# Altium schematic sheet RP2040_minimal.SchDoc(RP2040_minimal)
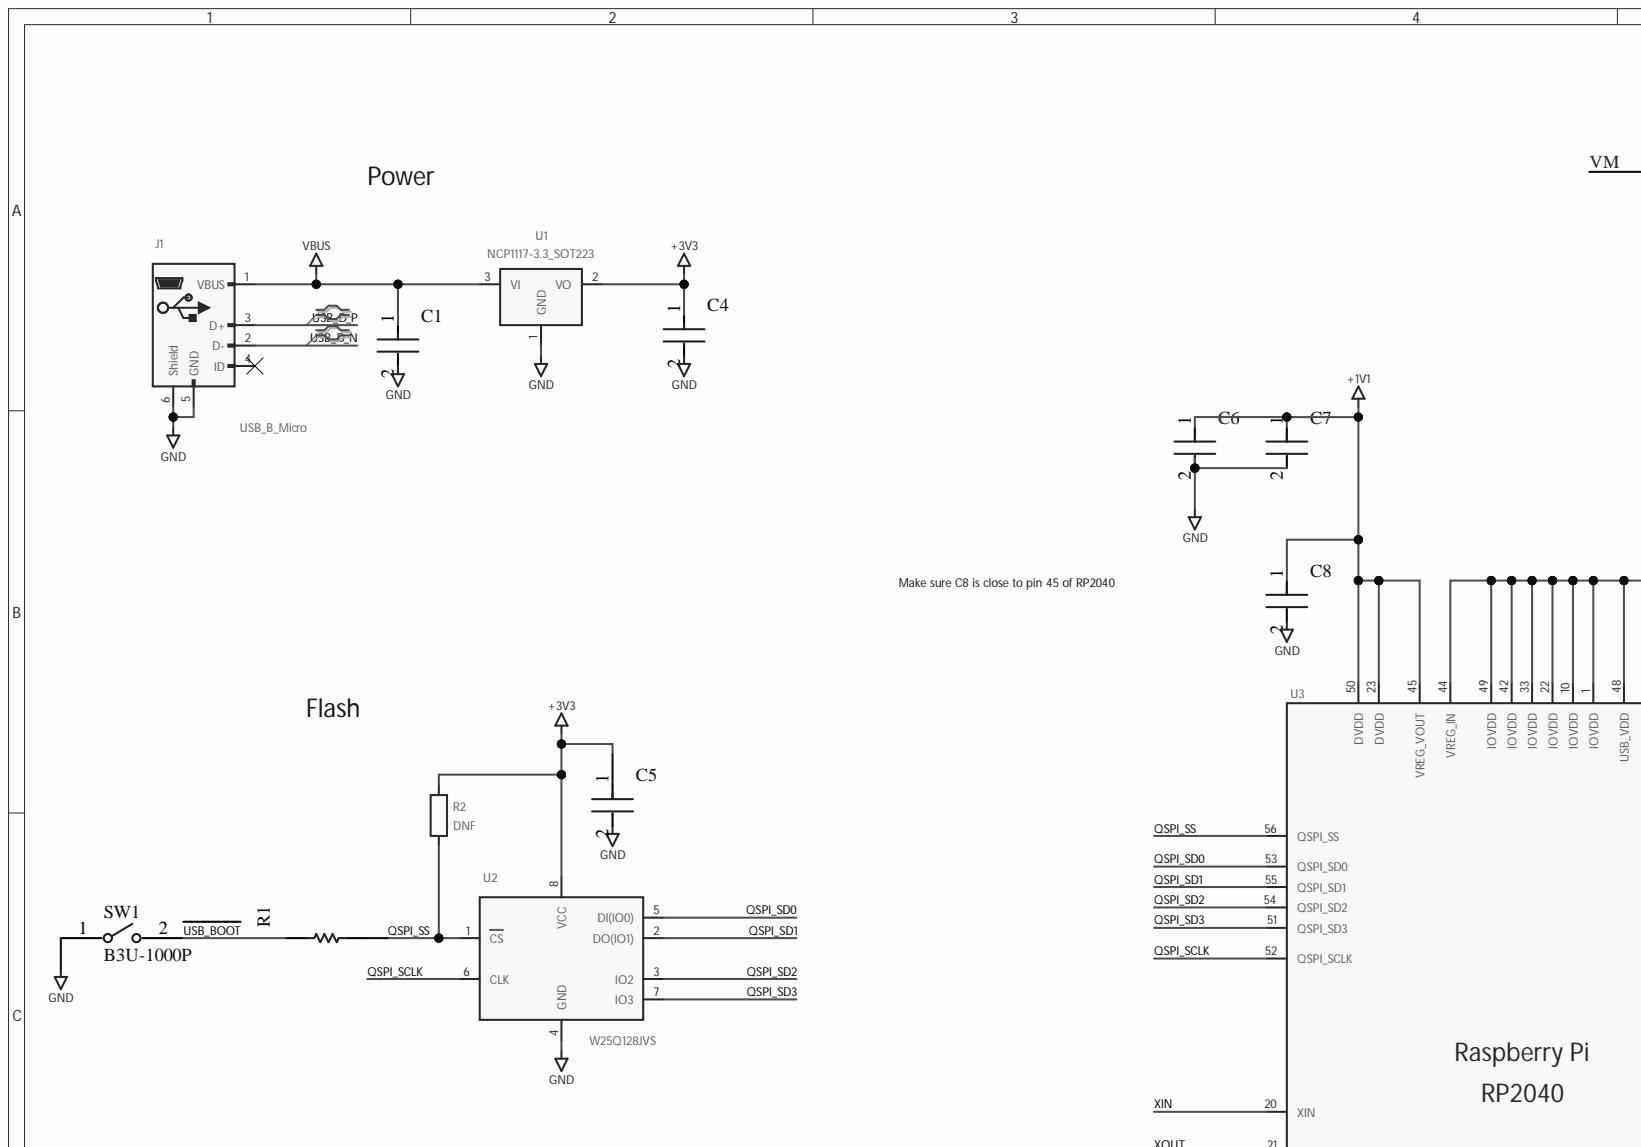
[diagram p0: RP2040_minimal.SchDoc, top left]
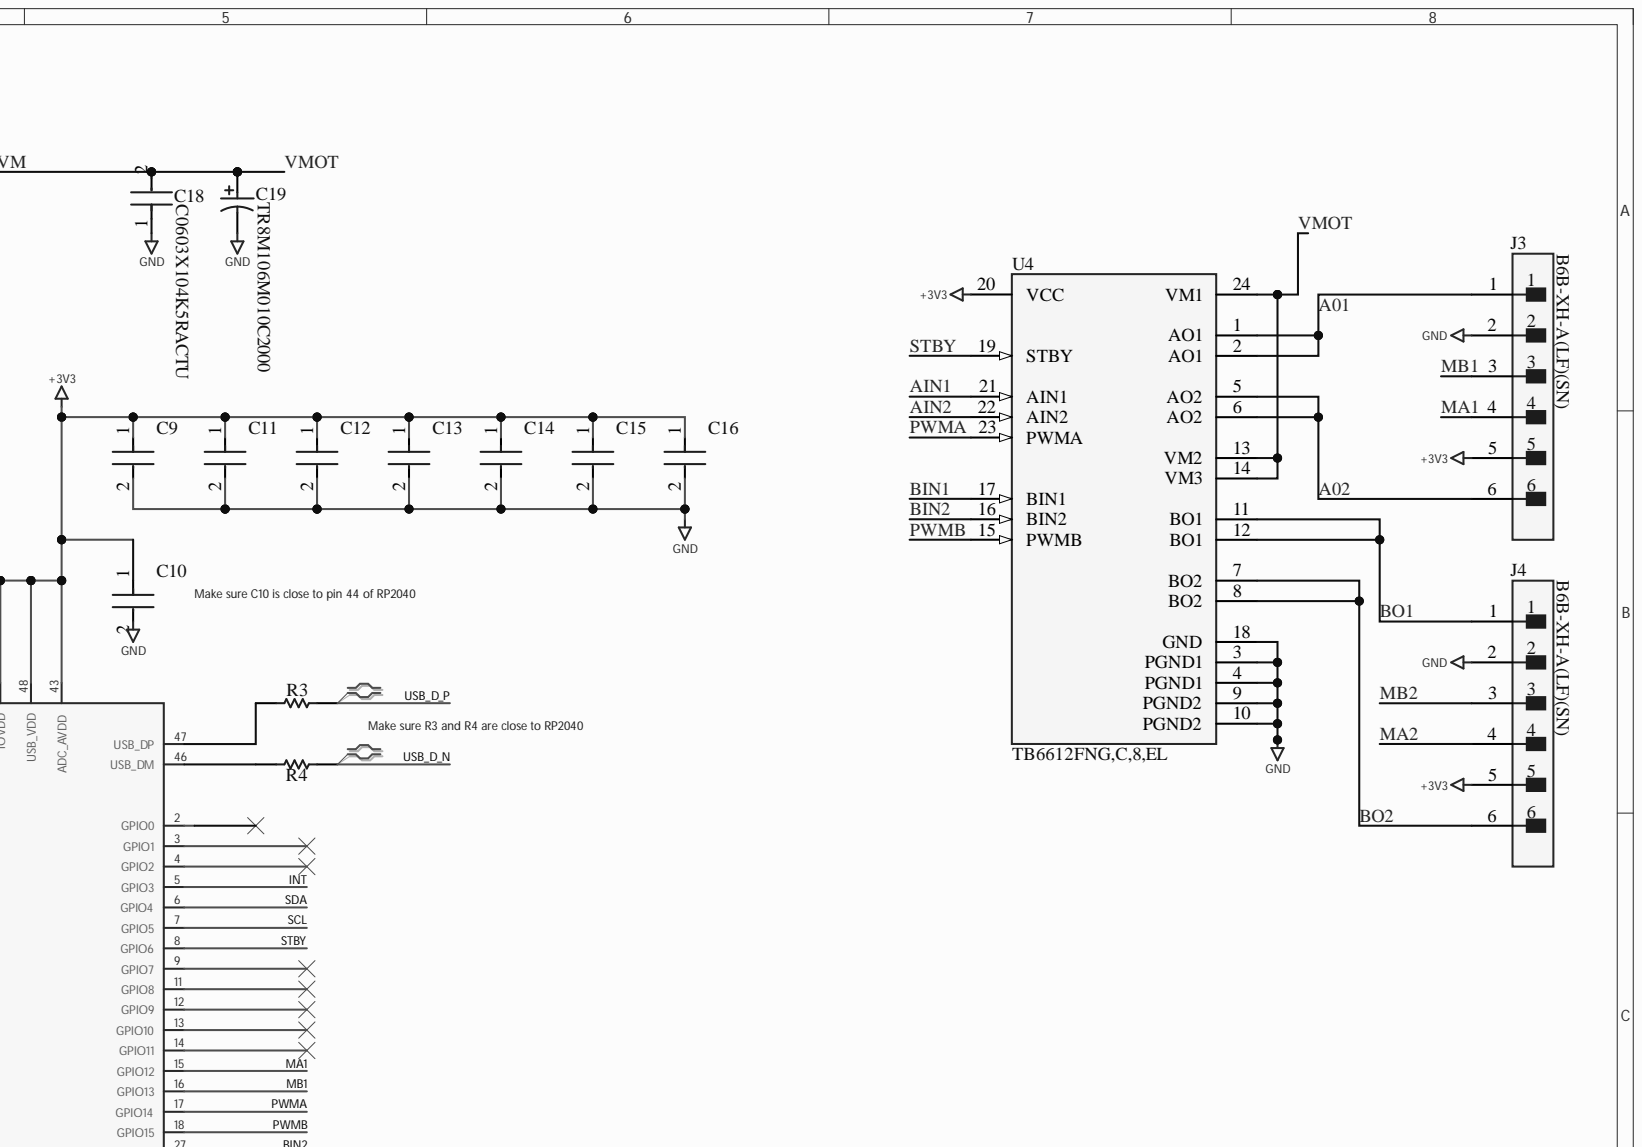
[diagram p1: RP2040_minimal.SchDoc, top right]
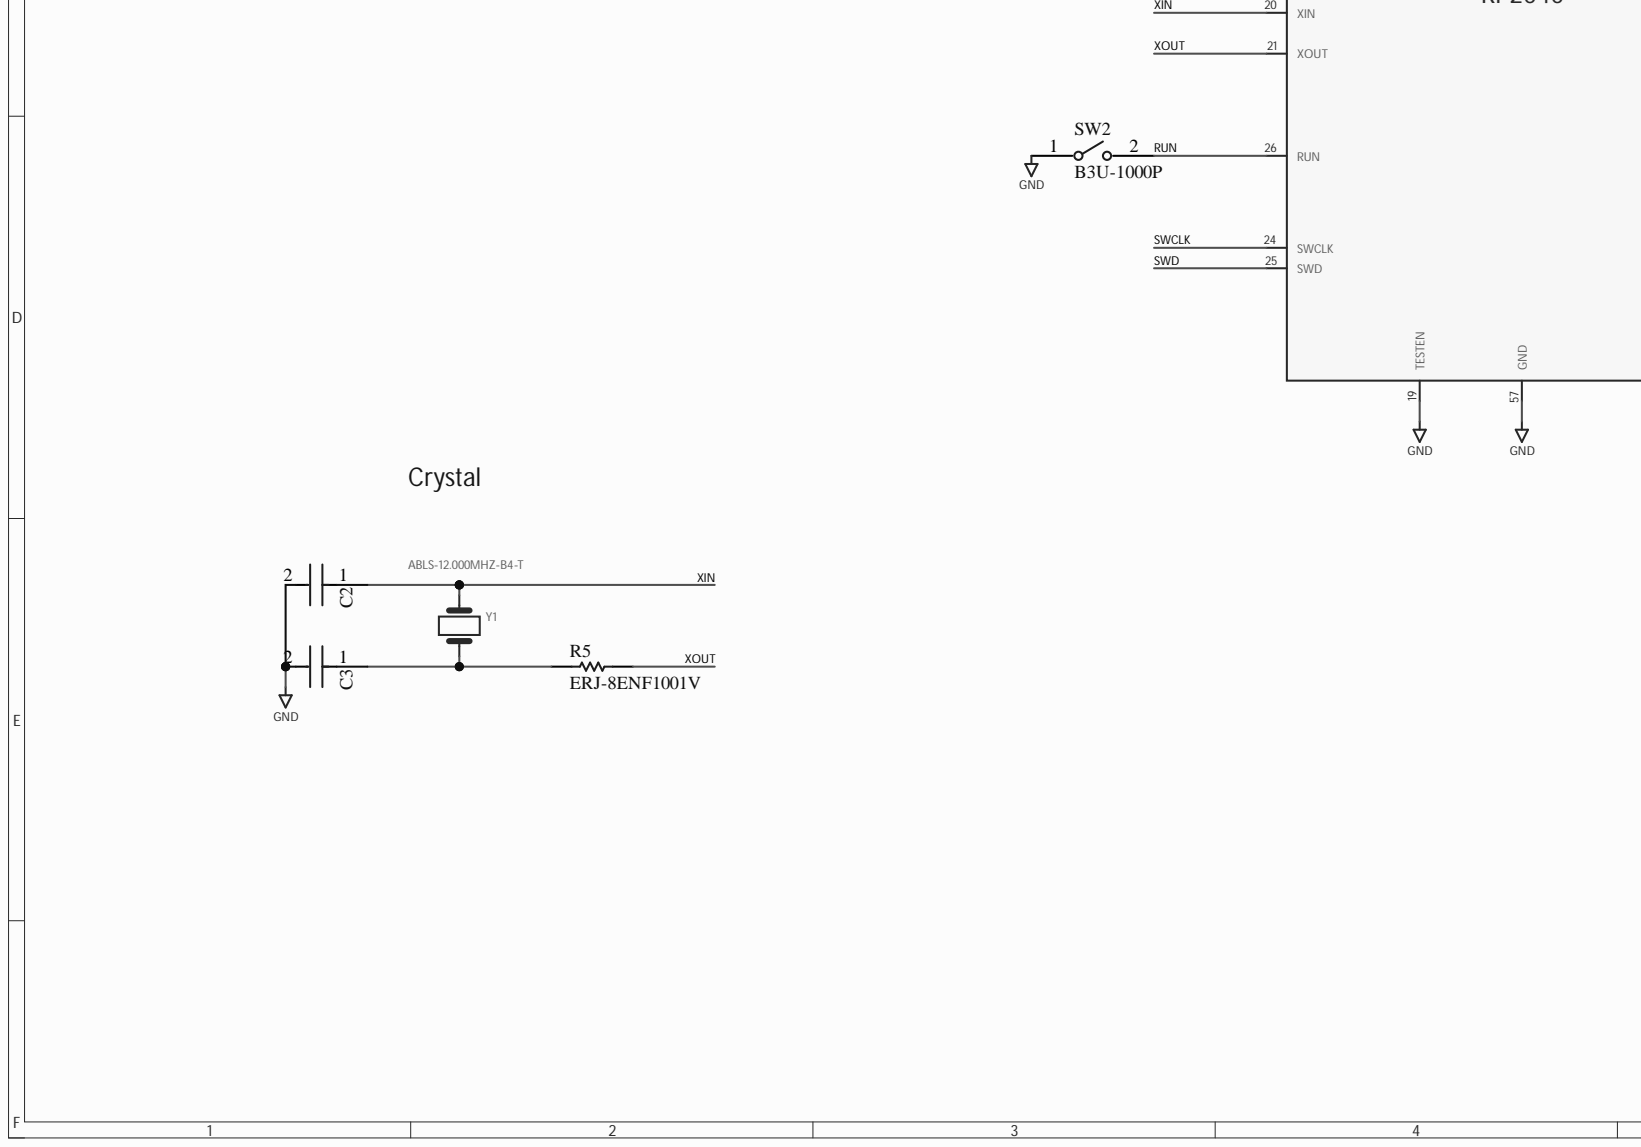
[diagram p2: RP2040_minimal.SchDoc, bottom left]
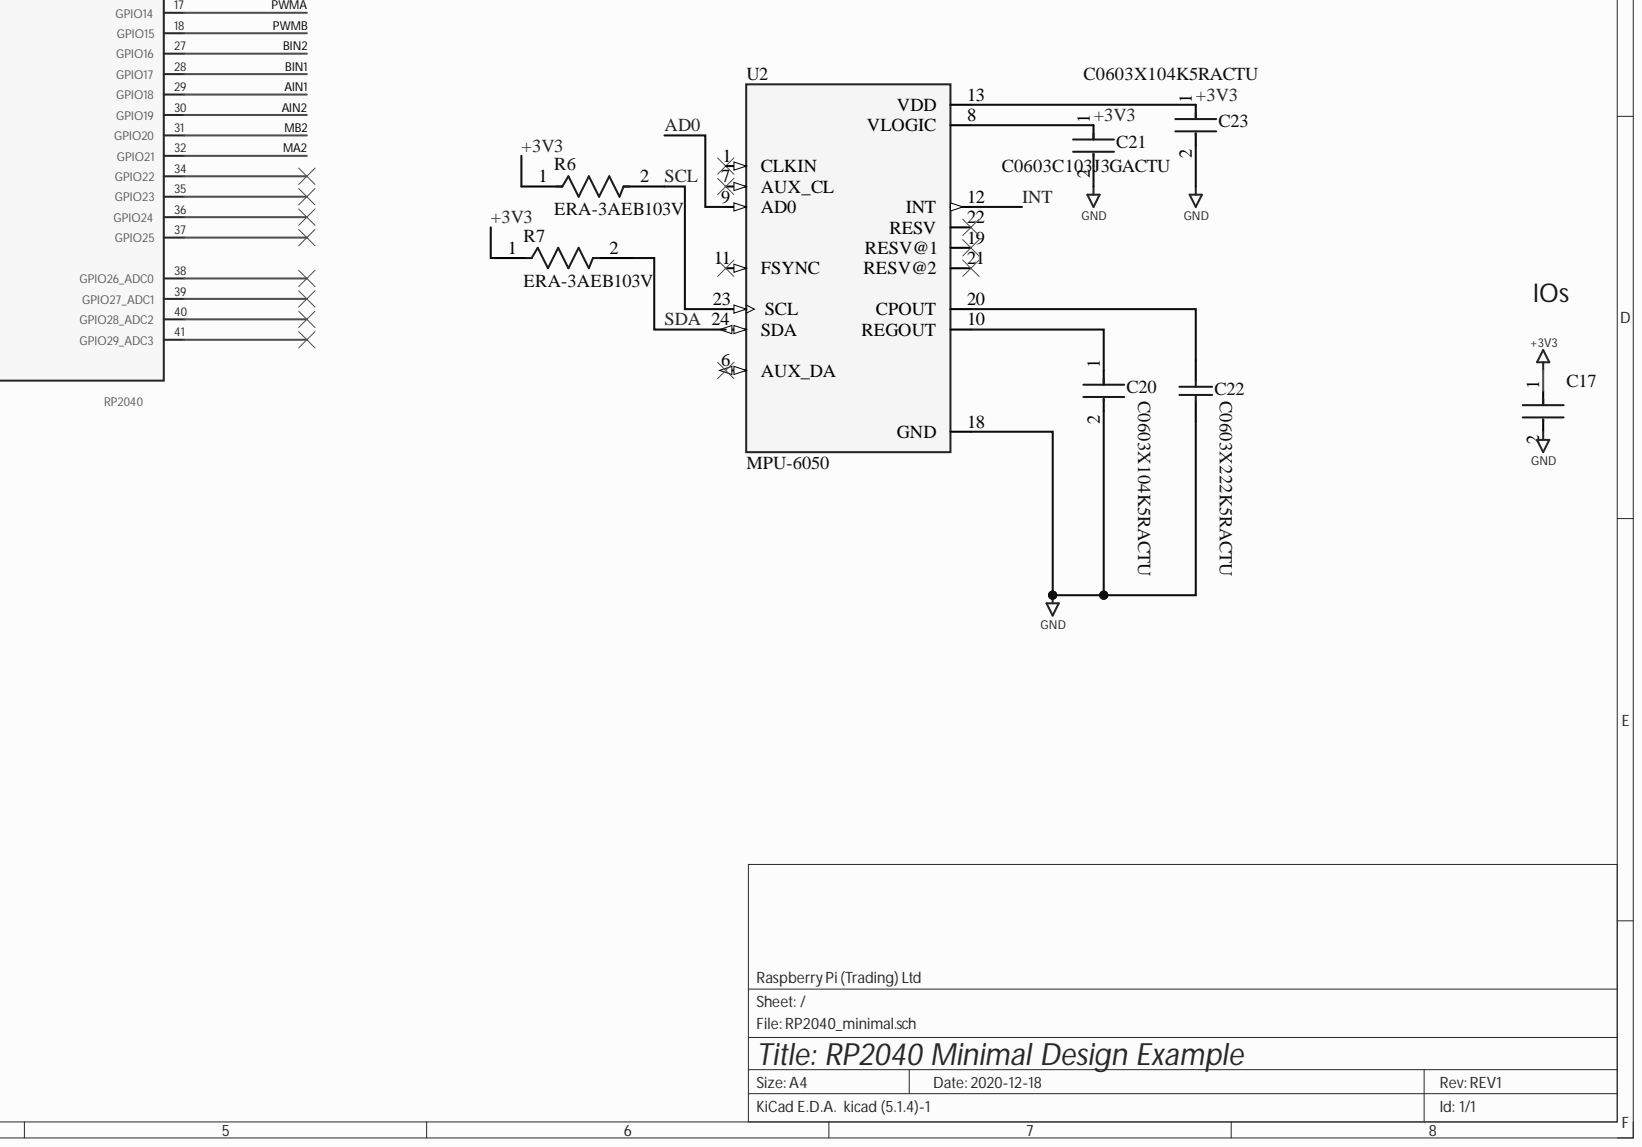
[diagram p3: RP2040_minimal.SchDoc, bottom right]

## Parts
C1  GRM21BR61C106KE15K  [FP-GRM21B-0_1-MFG]  (value not drawn)
C2  GCM1555C1H270JA16D  [FP-GCM155-0_05-IPC_B]  (value not drawn)
C3  GCM1555C1H270JA16D  [FP-GCM155-0_05-IPC_B]  (value not drawn)
C4  GRM21BR61C106KE15K  [FP-GRM21B-0_1-MFG]  (value not drawn)
C5  GRM155R71E104KE14J  [FP-GRM155-0_05-IPC_C]  (value not drawn)
C6  GRM155R71E104KE14J  [FP-GRM155-0_05-IPC_C]  (value not drawn)
C7  GRM155R71E104KE14J  [FP-GRM155-0_05-IPC_C]  (value not drawn)
C8  GCM1555C1H270JA16D  [FP-GCM155-0_05-IPC_B]  (value not drawn)
C9  GRM155R71E104KE14J  [FP-GRM155-0_05-IPC_C]  (value not drawn)
C10  GCM1555C1H270JA16D  [FP-GCM155-0_05-IPC_B]  (value not drawn)
C11  GRM155R71E104KE14J  [FP-GRM155-0_05-IPC_C]  (value not drawn)
C12  GRM155R71E104KE14J  [FP-GRM155-0_05-IPC_C]  (value not drawn)
C13  GRM155R71E104KE14J  [FP-GRM155-0_05-IPC_C]  (value not drawn)
C14  GRM155R71E104KE14J  [FP-GRM155-0_05-IPC_C]  (value not drawn)
C15  GRM155R71E104KE14J  [FP-GRM155-0_05-IPC_C]  (value not drawn)
C16  GRM155R71E104KE14J  [FP-GRM155-0_05-IPC_C]  (value not drawn)
C17  GRM21BR61C106KE15K  [FP-GRM21B-0_1-MFG]  (value not drawn)
C18  C0603X104K5RACTU  [FP-C0603-CJ-MFG]
C19  TR8M106M010C2000  [VISH-TR8-M_L]
C20  C0603X104K5RACTU  [FP-C0603-CJ-MFG]
C21  C0603C103J3GACTU  [FP-C0603C-CF-MFG]
C22  C0603X222K5RACTU  [CAPC1608X87X35NL15T15]
C23  C0603X104K5RACTU  [FP-C0603-CJ-MFG]
J1  USB_B_Micro  [RP2040_minimal:USB_Micro-B_Amphenol_10103594-0001LF_Horizontal_modified]
J3  B6B-XH-A(LF)(SN)  [FP-B6B-XH-A_LF_SN-MFG]
J4  B6B-XH-A(LF)(SN)  [FP-B6B-XH-A_LF_SN-MFG]
R1  RC0402FR-0727R4L  [RESC1005X40X25ML05T10]  (value not drawn)
R2  DNF  [Capacitor_SMD:C_0402_1005Metric]
R3  RC0402FR-0727R4L  [RESC1005X40X25ML05T10]  (value not drawn)
R4  RC0402FR-0727R4L  [RESC1005X40X25ML05T10]  (value not drawn)
R5  ERJ-8ENF1001V  [0402]
R6  ERA-3AEB103V  [FP-ERA3A-IPC_C]
R7  ERA-3AEB103V  [FP-ERA3A-IPC_C]
SW1  B3U-1000P  [FP-B3U-1000P-MFG]
SW2  B3U-1000P  [FP-B3U-1000P-MFG]
U1  NCP1117-3.3_SOT223  [Package_TO_SOT_SMD:SOT-223-3_TabPin2]
U2  MPU-6050  [QFN50P400X400X95-24N]
U2  W25Q128JVS  [Package_SO:SOIC-8_5.23x5.23mm_P1.27mm]
U3  RP2040  [RP2040_minimal:RP2040-QFN-56]
U4  TB6612FNG,C,8,EL  [FP-SSOP24-P-300-0_65A-IPC_A]
Y1  ABLS-12.000MHZ-B4-T  [RP2040_minimal:Crystal_SMD_HC49-US]

## Nets
+1V1: C6.1 C7.1 C8.1 U3.23(DVDD) U3.45(VREG_VOUT) U3.50(DVDD)
GND: C1.2 C2.2 C3.2 C4.2 C5.2 C6.2 C7.2 C8.2 C9.2 C10.2 C11.2 C12.2 C13.2 C14.2 C15.2 C16.2 C17.2 C18.1 C19.2 C20.2 C21.2 C22.2 C23.2 J1.5(GND) J1.6(Shield) J3.2 J4.2 SW1.1 SW2.1 U1.1 U2.4(GND) U2.18(GND) U3.19(TESTEN) U3.57(GND) U4.3(PGND1) U4.4(PGND1) U4.9(PGND2) U4.10(PGND2) U4.18(GND)
VBUS: C1.1 J1.1(VBUS) U1.3
+3V3: C4.1 C5.1 C9.1 C10.1 C11.1 C12.1 C13.1 C14.1 C15.1 C16.1 C17.1 C21.1 C23.1 J3.5 J4.5 R2.1 R6.1 R7.1 U1.2 U2.8 U2.13(VDD) U3.1(IOVDD) U3.10(IOVDD) U3.22(IOVDD) U3.33(IOVDD) U3.42(IOVDD) U3.43(ADC_AVDD) U3.44(VREG_IN) U3.48(USB_VDD) U3.49(IOVDD) U4.20(VCC)
A01: J3.1 U4.1(AO1) U4.2(AO1)
A02: J3.6 U4.5(AO2) U4.6(AO2)
AD0: U2.9(AD0)
AIN1: U3.29(GPIO18) U4.21(AIN1)
AIN2: U3.30(GPIO19) U4.22(AIN2)
BIN1: U3.28(GPIO17) U4.17(BIN1)
BIN2: U3.27(GPIO16) U4.16(BIN2)
BO1: J4.1 U4.11(BO1) U4.12(BO1)
BO2: J4.6 U4.7(BO2) U4.8(BO2)
INT: U2.12(INT) U3.5(GPIO3)
MA1: J3.4 U3.15(GPIO12)
MA2: J4.4 U3.32(GPIO21)
MB1: J3.3 U3.16(GPIO13)
MB2: J4.3 U3.31(GPIO20)
PWMA: U3.17(GPIO14) U4.23(PWMA)
PWMB: U3.18(GPIO15) U4.15(PWMB)
QSPI_SCLK: U2.6 U3.52(QSPI_SCLK)
QSPI_SD0: U2.5(DI(IO0)) U3.53(QSPI_SD0)
QSPI_SD1: U2.2(DO(IO1)) U3.55(QSPI_SD1)
QSPI_SD2: U2.3(IO2) U3.54(QSPI_SD2)
QSPI_SD3: U2.7 U3.51(QSPI_SD3)
QSPI_SS: R1.2 R2.2 U2.1 U3.56(QSPI_SS)
RUN: SW2.2 U3.26(RUN)
SCL: R6.2 U2.23(SCL) U3.7(GPIO5)
SDA: R7.2 U2.24(SDA) U3.6(GPIO4)
STBY: U3.8(GPIO6) U4.19(STBY)
SWCLK: U3.24(SWCLK)
SWD: U3.25(SWD)
~{USB_BOOT}: R1.1 SW1.2
USB_D_N: J1.2(D-) R4.2
USB_D_P: J1.3(D+) R3.2
VM: C18.2 C19.1 U4.13(VM2) U4.14(VM3) U4.24(VM1)
XIN: C2.1 U3.20(XIN) Y1.1
XOUT: R5.2 U3.21(XOUT)
NetC3_1: C3.1 R5.1 Y1.2
NetC20_1: C20.1 U2.10(REGOUT)
NetC22_1: C22.1 U2.20(CPOUT)
NetR3_1: R3.1 U3.47(USB_DP)
NetR4_1: R4.1 U3.46(USB_DM)

Not connected: J1 4(ID); U2 11(FSYNC) 19(RESV@1) 21(RESV@2) 22(RESV); U3 2(GPIO0) 3(GPIO1) 4(GPIO2) 9(GPIO7) 11(GPIO8) 12(GPIO9) 13(GPIO10) 14(GPIO11) 34(GPIO22) 35(GPIO23) 36(GPIO24) 37(GPIO25) 38(GPIO26_ADC0) 39(GPIO27_ADC1) 40(GPIO28_ADC2) 41(GPIO29_ADC3)

## Library data of the parts
C1 C4 C17 = CMP-2007-03534-2: Chip Multilayer Ceramic Capacitors for General Purpose, 0805, 10uF, X5R, 15%, 10%, 16V; Capacitance: 10uF; Case Code (Imperial): 0805; Case Code (Metric): 2012; Case/Package: 0805; Component Kind: Standard; Depth: 1.25mm; Dielectric: X5R; Height: 1.25mm; Length: 2mm; Library Name: Altium Content Vault; Max Operating Temperature: 85°C; Min Operating Temperature: -55°C; Mount: Surface Mount; Package Quantity: 10000; Packaging: Tape and Reel; Radiation Hardening: No; REACH SVHC: No SVHC; Termination: SMD/SMT; Thickness: 0.053inch; Tolerance: 10%; Voltage: 16V; Voltage Rating: 16V; Voltage Rating (DC): 16V; Width: 0.049inch
C2 C3 C8 C10 = CMP-06035-017587-1: Ceramic Capacitor for Automotive 27pF ±5% 50VDC C0G 0402 Paper T/R; Voltage Rating: 50V; Capacitance: 27pF; Case Code (Imperial): 0402; Case Code (Metric): 1005; Case/Package: 0402; Component Kind: Standard; Dielectric: C0G; Height: 0.5mm; Length: 0.039inch; Library Name: Altium Content Vault; Max Operating Temperature: 125°C; Min Operating Temperature: -55°C; Mount: Surface Mount; Package Quantity: 10000; Packaging: Tape and Reel; Ratings: AEC-Q200; Termination: SMD/SMT; Thickness: 0.022inch; Tolerance: 5%; Voltage Rating (DC): 50V; Weight: 0.000212oz; Width: 0.02inch
C5-C7 C9 C11-C16 = CMP-06035-003995-1: Chip Multilayer Ceramic Capacitors for General Purpose, 0402, 0.10uF, X7R, 15%, 10%, 25V; Capacitance: 100nF; Case Code (Imperial): 0402; Case Code (Metric): 1005; Case/Package: 0402; Component Kind: Standard; Dielectric: X7R; Height: 0.5mm; Length: 0.039inch; Library Name: Altium Content Vault; Max Operating Temperature: 125°C; Min Operating Temperature: -55°C; Mount: Surface Mount; Package Quantity: 50000; Packaging: Tape and Reel; Resistor Type: Ceramic; Termination: SMD/SMT; Thickness: 0.022inch; Tolerance: 10%; Voltage Rating: 25V; Voltage Rating (DC): 25V; Weight: 0.000212oz; Width: 0.5mm
C18 C20 C23 = CMP-2006-03300-2: CAP CER 0.1UF 50V X7R 0603; Manufacturer: Kemet; Capacitance: 100nF; Material: Ceramic; Temperature Coefficient: 15%; Tolerance: 10%; Value: 100nF; Automotive: No; Category: Cap; Component Kind: Standard; ComponentLink2Description: Datasheet; ComponentLink2URL: https://content.kemet.com/datasheets/KEM_C1013_X7R_FT-CAP_SMD.pdf; Device Class L1: Passive Components; Device Class L2: Capacitors; Device Class L3: Ceramic Capacitors; Digikey Description: CAP CER 0.1UF 50V X7R 0603; Height: 0.95mm; Ipc Land Pattern Name: CAPC16080X80; Lead Free: Yes; Library Name: Altium Content Vault; Manufacturer Part Number: C0603X104K5RACTU; Mouser Description: Capacitor; Ceramic; Cap 0.1uF; Tol+10%; SMT; Flexible Termination; Vol-Rtg 50V; TR; Octopart: https://octopart.com/c0603x104k5ractu-kemet-9145062; Package: C0603-CJ; RoHS: TRUE; Temperature: -55°C to +125°C; Temperature Characteristic: X7R; Temperature Range High: +125°C; Temperature Range Low: -55°C; Voltage: 50V; Voltage Rating: 50V
C19 = CMP-2000-07316-1: Tantalum Capacitor, 10 uF, +/- 20%, 10 V, -55 to 125 degC, 2-Pin SMD (M), RoHS, Tape and Reel; Manufacturer: Vishay; Capacitance: 10µF; Catalog Drawings: TR8SeriesTR8SeriesBottom; ESR (Equivalent Series Resistance): 2Ohm; Featured Product: TR8SeriesMoldedMicroTan™TantalumChipCapacitors; Online Catalog: MICROTAN®TR8Series; Other Names: 718-1673-6; Type: Molded; Voltage - Rated: 10V; Category: Capacitors; Component Kind: Standard; Datasheet URL: http://www.vishay.com/docs/40114/tr8.pdf; Datasheet Version: 03/2016; Family: TantalumCapacitors; Features: GeneralPurpose; Height - Seated (Max): 0.035"(0.89mm); Lead Spacing: -; Library Name: Altium Content Vault; Lifetime @ Temp.: -; Manufacturer Size Code: M; Manufacturer URL: http://www.vishay.com/; Mounting Technology: SurfaceMount; Mounting Type: SurfaceMount; Operating Temperature: -55°C~125°C; Package / Case: 0603(1608Metric); Package Description: 2-Pin Surface Mount Device, Body 1.6 x 0.85 mm; Package Reference: TR8-M; Packaging: Digi-Reel®; Series: MICROTAN®TR8; Size / Dimension: 0.063"Lx0.033"W(1.60mmx0.85mm); Standard Package: 1; Tolerance: ±20%
C21 = CMP-2006-03705-3: CAP CER 10000PF 25V 5% NP0 0603; Manufacturer: KEMET; Automotive Grade: Grade 1; Capacitance: 10,000 pF; Material: Ceramic; Temperature Characteristic: C0G; Temperature Coefficient: 30ppm/°C; Tolerance: 0.05; Value: 10,000 pF; Automotive: Yes; Category: Cap; Component Kind: Standard; ComponentLink2Description: Datasheet; ComponentLink2URL: https://api.kemet.com/component-edge/download/datasheet/C0603C100J5GACAUTO.pdf; Device Class L1: Passive Components; Device Class L2: Capacitors; Device Class L3: Ceramic Capacitors; Digikey Description: CAP CER 10000PF 25V 5% NP0 0603; Height: 0.87mm; Lead Free: Yes; Library Name: Altium Content Vault; Manufacturer Part Number: C0603C103J3GACTU; Mouser Description: 0603 C 10nF Ceramic Multilayer Capacitor, 25 V, +125degC, C0G Dielectric, +/-5%; Octopart: https://octopart.com/c0603c103j3gactu-kemet-10686801; Package: C0603C-CF; RoHS: TRUE; Temperature: -55°C to +125°C; Temperature Range High: +125°C; Temperature Range Low: -55°C; Voltage Rating: 25 V
C22 = CMP-2006-03302-1: Manufacturer: Kemet; Component Kind: Standard; Library Name: Altium Content Vault; Part Number: C0603X222K5RACTU
J3 J4 = CMP-17439-000076-1: CONN HEADER VERT 6POS 2.5MM; Component Kind: Standard; Library Name: Altium Content Vault
R1 R3 R4 = CMP-2002-07553-1: Manufacturer: Yageo / Phycomp; Component Kind: Standard; Library Name: Altium Content Vault; Part Number: RC0402FR-0727R4L
R2 = R: Component Kind: Standard; Library Name: Device; Value: DNF
R5 = RES: Manufacturer: Panasonic; Component Kind: Standard; Part Number: ERJ-8ENF1001V; Library Name: RP2040_minimal.SchLib
R6 R7 = CMP-2000-00874-2: RES SMD 10K OHM 0.1% 1/10W 0603; Max Power Dissipation: 100mW; Resistance: 10kR; Temperature Coefficient: 25ppm/°C; Voltage Rating: 75V; Case Code (Imperial): 0603; Case Code (Metric): 1608; Case/Package: 0603; Component Kind: Standard; Construction: Rectangular; Contact Plating: Tin; Depth: 0.8mm; Height: 0.45mm; Lead Free: Lead Free; Length: 1.6mm; Library Name: Altium Content Vault; Max Operating Temperature: 155°C; Military Standard: Not; Min Operating Temperature: -55°C; Mount: Surface Mount; Number of Pins: 2; Number of Terminations: 2; Package Quantity: 5000; Packaging: Tape and Reel; Power Rating: 100mW; Radiation Hardening: No; REACH SVHC: No SVHC; Resistance Tolerance: 0.1%; Resistor Type: Thin Film; RoHS Compliant: Yes; Series: ERA-3A; Size Code: 0603; Technology: METAL FILM; Termination: SMD/SMT; Tolerance: 0.1%; Voltage Rating (DC): 75V; Width: 0.8mm
SW1 SW2 = CMP-2100-03586-2: SWITCH TACTILE SPST-NO 0.05A 12V; Actuator Length: 0.4mm; Actuator Type: Standard; Contact Current Rating: 50mA; Contact Resistance: 100mR; Electrical Life: 200000Cycles; Illumination Type: Non-Illuminated; Operating Force: 1.5N; Ratings: Dust Proof; Switch Function: Off-Mom; Switch Travel: 0.15mm; Throw Configuration: SPST; Component Kind: Standard; Contact Material: Silver; Current Rating: 50mA; Depth: 2.5mm; Height: 1.2mm; Insulation Resistance: 100MR; Lead Free: Lead Free; Length: 4mm; Library Name: Altium Content Vault; Max Current Rating: 50mA; Max Operating Temperature: 70°C; Max Voltage Rating (DC): 12V; Mechanical Life: 200000Cycles; Min Operating Temperature: -25°C; Mount: Surface Mount; Orientation: Straight; Package Quantity: 1; Packaging: Tape and Reel; Termination: SMD/SMT; Voltage Rating (DC): 12V; Weight: 0.000776oz; Width: 3mm
U2 = MPU-6050: MPU-6050 series Accelerometer, Gyroscope, 3 Axis Sensor Evaluation Board; MF: TDK InvenSense; Availability: In Stock; Check_prices: https://www.snapeda.com/parts/MPU-6050/TDK+InvenSense/view-part/?ref=eda; Component Kind: Standard; Library Name: MPU-6050.IntLib; MP: MPU-6050; Package: QFN-24 InvenSense; Price: None; Purchase-URL: https://pricing.snapeda.com/search/part/MPU-6050/?ref=eda; SnapEDA_Link: https://www.snapeda.com/parts/MPU-6050/TDK+InvenSense/view-part/?ref=snap
U4 = CMP-2100-03693-4: IC MOTOR DRIVER 2.7V-5.5V 24SSOP; Interface: Parallel; Logic Function: AND GATE; Max Supply Voltage: 5.5V; Min Supply Voltage: 2.7V; Number of Outputs: 2; Operating Supply Voltage: 3V; Output Current: 1A; Case/Package: SSOP; Component Kind: Standard; Library Name: Altium Content Vault; Max Operating Temperature: 85°C; Min Operating Temperature: -20°C; Mount: Surface Mount; Number of Pins: 24; Package Quantity: 1; Packaging: Tape and Reel; Series: -
Y1 = Crystal: Component Kind: Standard; Library Name: Device; Value: ABLS-12.000MHZ-B4-T
J1 = USB_B_Micro: Component Kind: Standard; Library Name: Connector; Value: USB_B_Micro
U1 = NCP1117-3.3_SOT223: Component Kind: Standard; Library Name: Regulator_Linear; Value: NCP1117-3.3_SOT223
U2 = W25Q128JVS: Component Kind: Standard; Value: W25Q128JVS; Library Name: RP2040_minimal.SchLib
U3 = RP2040: Component Kind: Standard; Library Name: MCU_RaspberryPi_RP2040; Value: RP2040
